# Altium schematic sheet Power_Regulation.SchDoc(Power_Regulation)
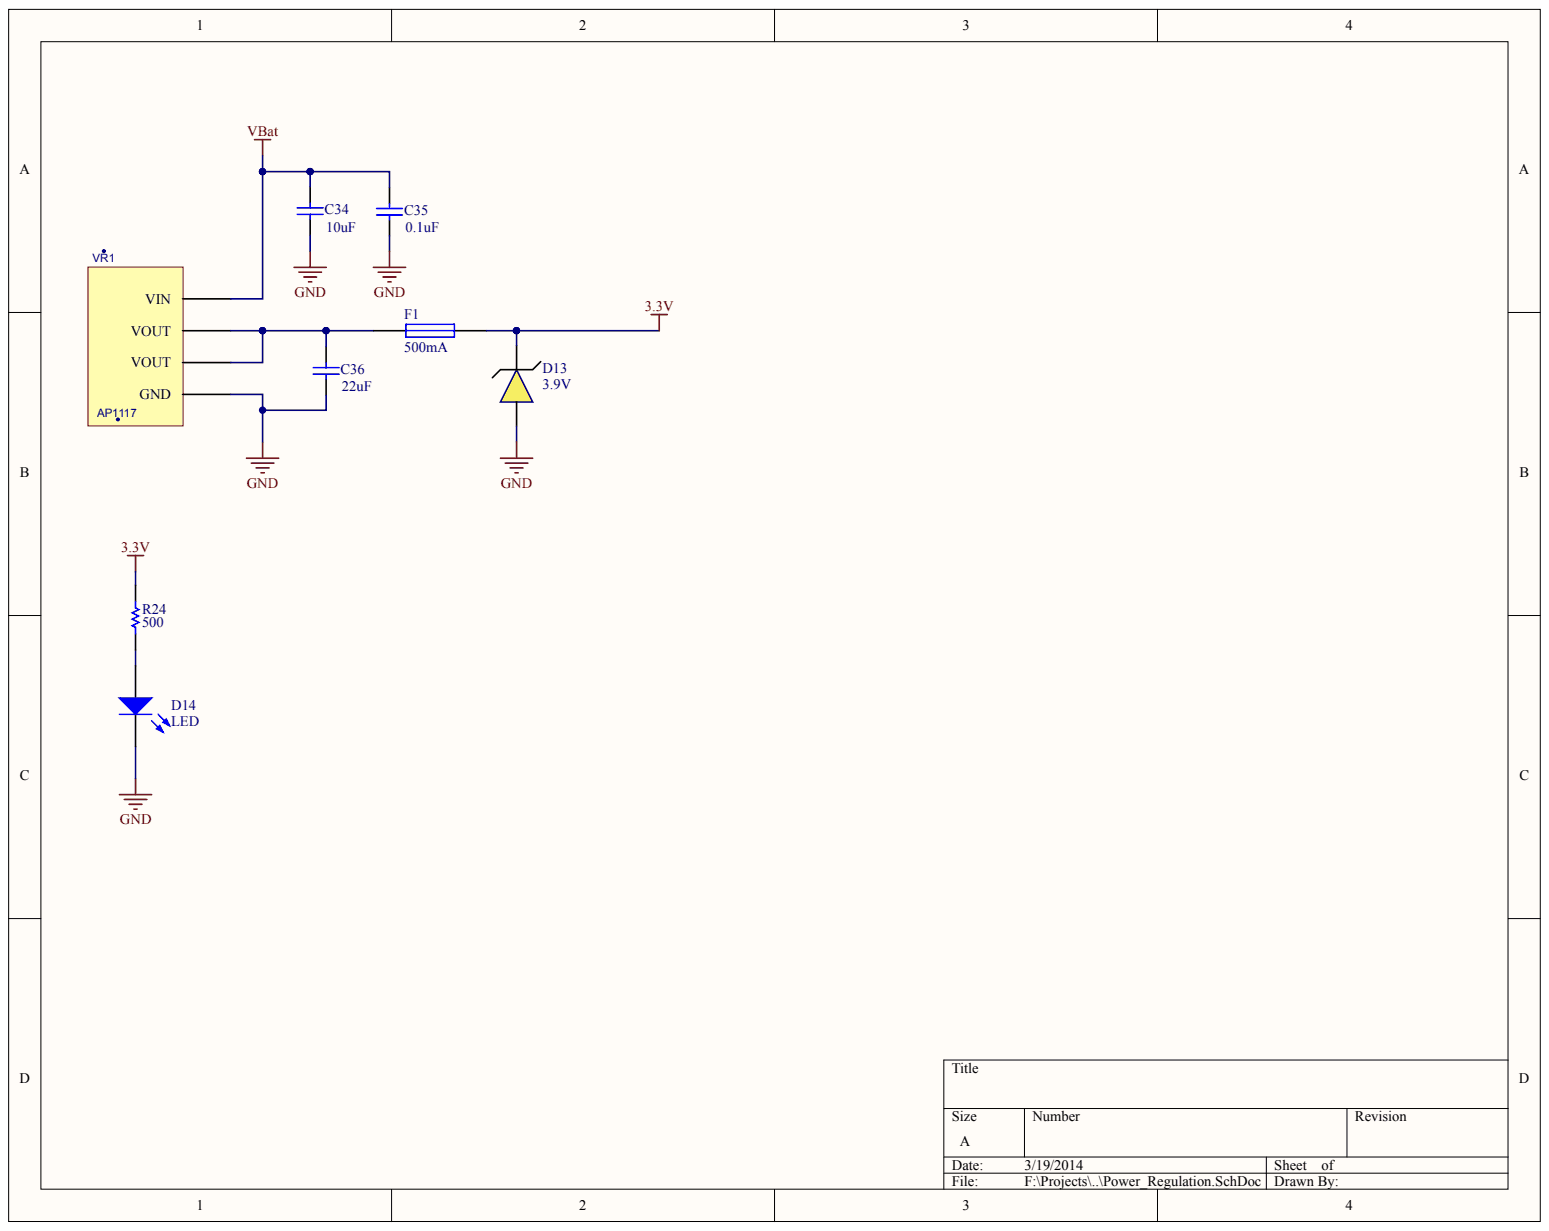
[diagram p0: Power_Regulation.SchDoc]

## Parts
C34  value 10uF  (hidden comment: Cap Semi)
C35  value 0.1uF  (hidden comment: Cap Semi)
C36  value 22uF  (hidden comment: Cap Semi)
D13  3.9V
D14  LED
F1  500mA
R24  value 500  (hidden comment: Resistor)
VR1  AP1117

## Nets
3.3V: D13.1 F1.2 R24.2
GND: C34.1 C35.1 C36.1 D13.2 D14.2 VR1.1(GND)
VBAT: C34.2 C35.2 VR1.3(VIN)
NetC36_2: C36.2 F1.1 VR1.2(VOUT) VR1.4(VOUT)
NetD14_1: D14.1 R24.1

## Library data of the parts
D14 = (no symbol name): Vendor Part Number: 751-1203-ND; Manufacturer: Vishay; Manufacturer Part Number: TSAL6100; Vendor: Digikey; Published: 16-Mar-2013; LatestRevisionDate: 16-Mar-2013; LatestRevisionNote: Created; PackageReference: LED; PackageDescription: 5mm LED; 2 Leads; Publisher: Anthony Merlino
C34-C36 D13 F1 R24 VR1 = (no symbol name)
  C34-C36: Published: 8-Jun-2000; LatestRevisionDate: 29-May-2009; LatestRevisionNote: IPC-7351 Footprint Added.; PackageReference: C1206; PackageDescription: Chip Capacitor
  F1: Published: 8-Jun-2000; LatestRevisionDate: 17-Jul-2002; LatestRevisionNote: Re-released for DXP Platform.; PackageReference: PIN-W2/E2.8; PackageDescription: Thru-Hole; 2 Leads
  R24: Published: 8-Jun-2000; LatestRevisionDate: 17-Jul-2002; LatestRevisionNote: Re-released for DXP Platform.; PackageReference: AXIAL-0.3
  VR1: Value: *
  C34-C36 F1 R24: Publisher: Altium Limited
